# Altium schematic sheet PDM-S01-V00-IsolatedCAN.SchDoc
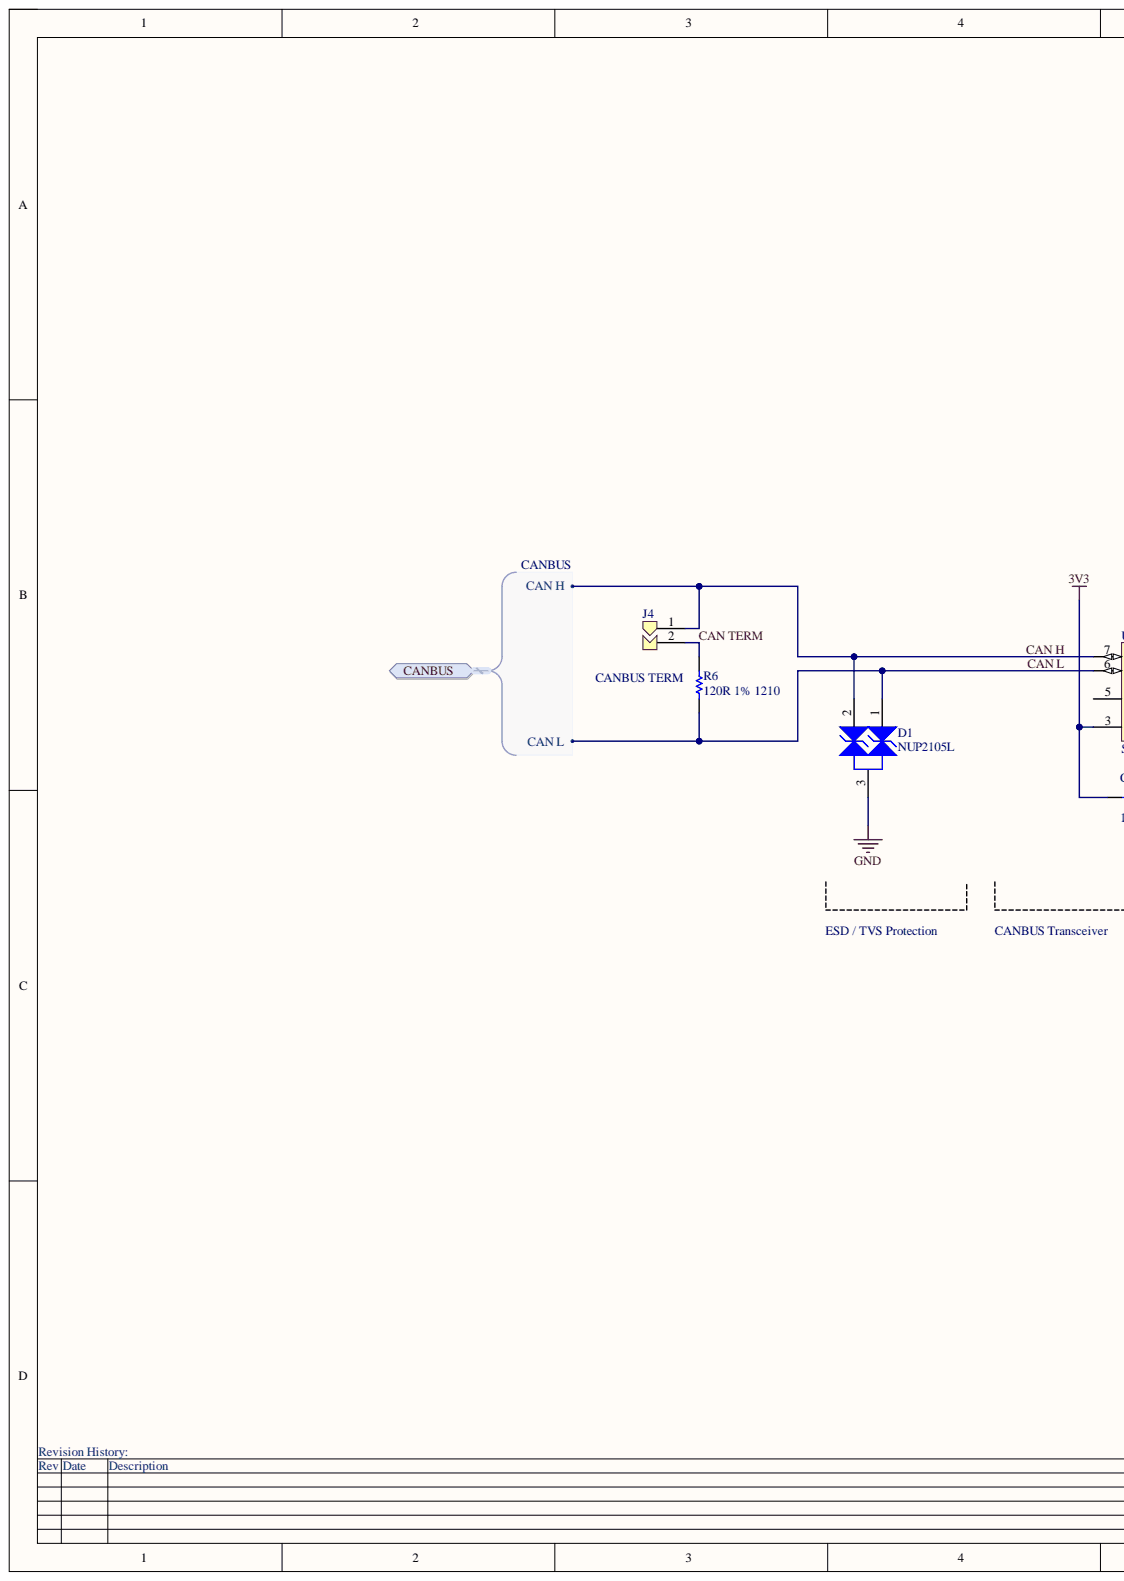
[diagram p0: PDM-S01-V00-IsolatedCAN.SchDoc, left]
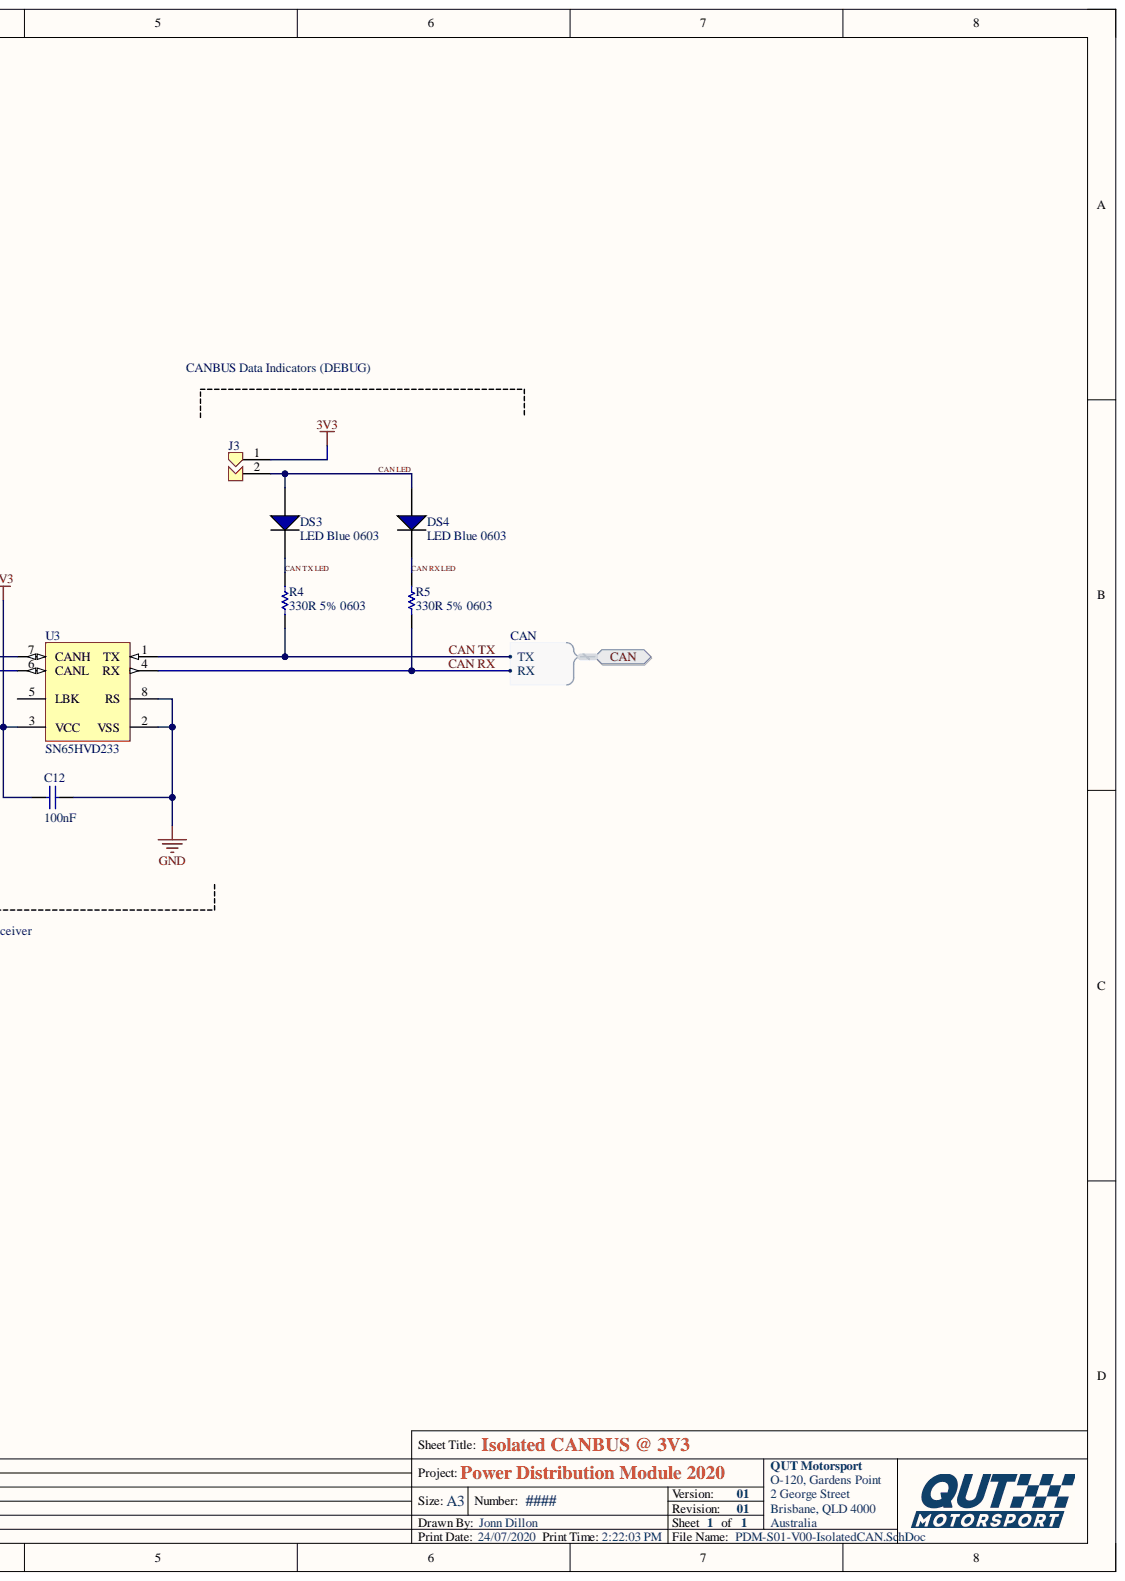
[diagram p1: PDM-S01-V00-IsolatedCAN.SchDoc, right]

## Parts
C12  100nF  [Cap_Ceramic_0603]
D1  NUP2105L  [NUP2105L]
DS3  LED Blue 0603  [LED BLUE 0603]
DS4  LED Blue 0603  [LED BLUE 0603]
J3  Jumper 2Way Solderable  [Jumper_2Way_Solderable]  (value not drawn)
J4  Jumper 2Way Solderable  [Jumper_2Way_Solderable]  (value not drawn)
R4  330R 5% 0603  [Res_ThinFilm_0603]
R5  330R 5% 0603  [Res_ThinFilm_0603]
R6  120R 1% 1210  [Res_ThinFilm_1210]
U3  SN65HVD233  [SN65HVD233DR]

## Ports
CAN, CAN.RX, CAN.TX, CANBUS, CANBUS.CAN H, CANBUS.CAN L

## Nets
3V3: C12.2 J3.1 U3.3(VCC)
GND: C12.1 D1.3 U3.2(VSS) U3.8(RS)
CAN H: D1.2 J4.1 U3.7(CANH) ; port CANBUS
CAN L: D1.1 R6.1 U3.6(CANL) ; port CANBUS
CAN LED: DS3.1 DS4.1 J3.2
CAN RX: R5.1 U3.4(RX) ; port CAN
CAN RX LED: DS4.2 R5.2
CAN TERM: J4.2 R6.2
CAN TX: R4.1 U3.1(TX) ; port CAN
CAN TX LED: DS3.2 R4.2

Not connected: U3 5(LBK)

## Library data of the parts
C12 = 100nF 50V 5% X7R 0603: Capacitor, Ceramic, SMD, 100nF, 50V, 5%, X7R, 0603; Component Kind: Standard; Library Name: StandardCapacitors.SchLib
J3 J4 = Jumper 2Way Side Solderable: Component Kind: Standard; Description: Jumper 2Way Side Solderable; Library Name: QUTMS_JumperLibrary.SchLib
R4 R5 = 330R 5% 0603: Resistor, SMD, 330R, 0.1W, 5%, 0603; Component Kind: Standard; Library Name: StandardResistors.SchLib
R6 = 120R 1% 1210: Resistor, SMD, 120R, 0.25W, 1%, 1210; Component Kind: Standard; Library Name: StandardResistors.SchLib
D1 = NUP2105L: Component Kind: Standard; ComponentLink1Description: Datasheet; ComponentLink1URL: https://www.onsemi.cn/PowerSolutions/document/NUP2105L-D.PDF; ComponentLink2Description: LCSC; ComponentLink2URL: https://lcsc.com/product-detail/TVS_ON-Semiconductor-NUP2105LT1G_C14486.html; ComponentLink3Description: Digikey; ComponentLink3URL: https://www.digikey.com.au/product-detail/en/on-semiconductor/SZNUP2105LT1G/SZNUP2105LT1GOSCT-ND/3487669; Description: NUP2105L; Library Name: QUTMS_CANLibrary.SchLib
DS3 DS4 = LED BLUE 0603: Component Kind: Standard; Library Name: QUTMS_LEDLibrary.SchLib
U3 = SN65HVD233: Component Kind: Standard; ComponentLink1Description: LCSC; ComponentLink1URL: https://lcsc.com/product-detail/CAN-ICs_Texas-Instruments_SN65HVD233DRG4_Texas-Instruments-Texas-Instruments-SN65HVD233DRG4_C61643.html; ComponentLink2Description: Digikey; ComponentLink2URL: https://www.digikey.com.au/product-detail/en/texas-instruments/SN65HVD233DR/296-35967-1-ND/4134960; ComponentLink3Description: Datasheet; ComponentLink3URL: https://www.ti.com/lit/ds/symlink/sn65hvd233.pdf?ts=1588560888178; ComponentLink4Description: Ti Product Page; ComponentLink4URL: https://www.ti.com/product/SN65HVD233; Description: SN65HVD233; Library Name: QUTMS_CANLibrary.SchLib
